# Altium schematic sheet Sensor.SchDoc
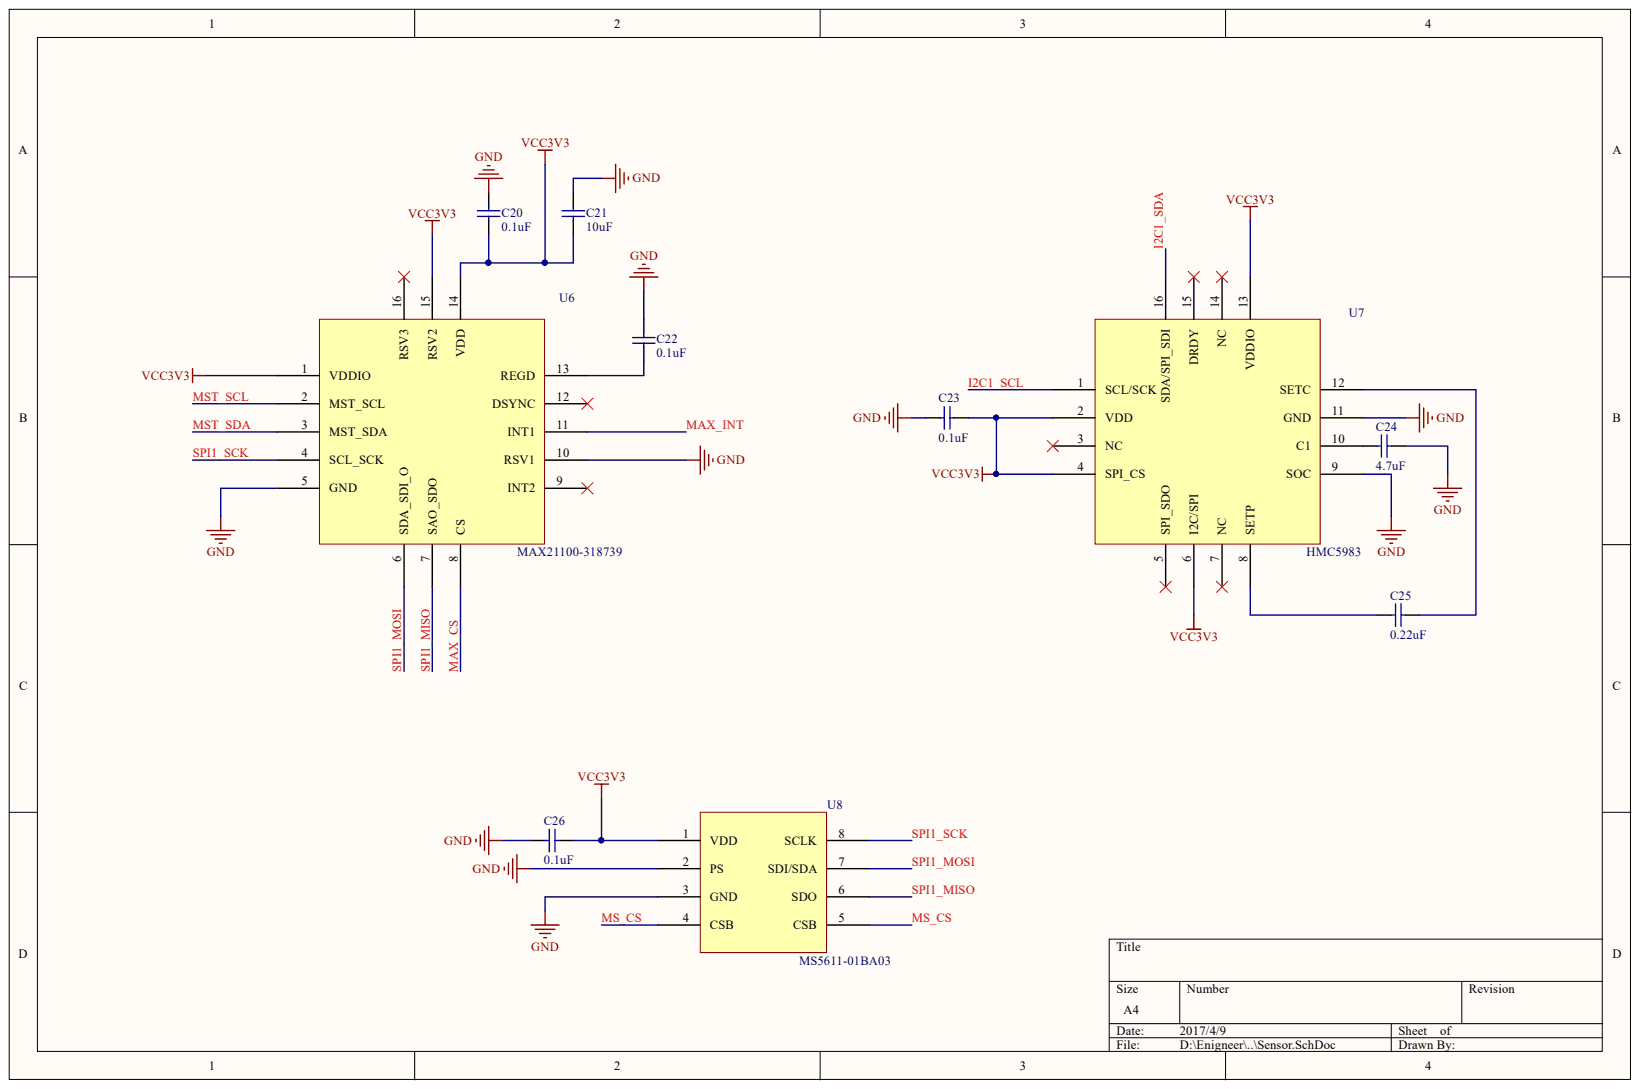
[diagram p0: Sensor.SchDoc]

## Parts
C20  value 0.1uF  [0603C]  (hidden comment: Cap)
C21  value 10uF  [0603C]  (hidden comment: Cap)
C22  value 0.1uF  [0603C]  (hidden comment: Cap)
C23  value 0.1uF  [0603C]  (hidden comment: Cap)
C24  value 4.7uF  [0603C]  (hidden comment: Cap)
C25  value 0.22uF  [0603C]  (hidden comment: Cap)
C26  value 0.1uF  [0603C]  (hidden comment: Cap)
U6  MAX21100-318739  [MAX21100-LCC]
U7  HMC5983  [HMC5983-LCC]
U8  MS5611-01BA03  [MS5611-QFN]

## Nets
GND: C20.2 C21.2 C22.2 C23.2 C24.1 C26.2 U6.5(GND) U6.10(RSV1) U7.9(SOC) U7.11(GND) U8.2(PS) U8.3(GND)
VCC3V3: C20.1 C21.1 C23.1 C26.1 U6.1(VDDIO) U6.14(VDD) U6.15(RSV2) U7.2(VDD) U7.4(SPI_CS) U7.6(I2C/SPI) U7.13(VDDIO) U8.1(VDD)
I2C1_SCL: U7.1(SCL/SCK)
I2C1_SDA: U7.16(SDA/SPI_SDI)
MAX_CS: U6.8(CS)
MAX_INT: U6.11(INT1)
MS_CS: U8.4(CSB) U8.5(CSB)
MST_SCL: U6.2(MST_SCL)
MST_SDA: U6.3(MST_SDA)
SPI1_MISO: U6.7(SAO_SDO) U8.6(SDO)
SPI1_MOSI: U6.6(SDA_SDI_O) U8.7(SDI/SDA)
SPI1_SCK: U6.4(SCL_SCK) U8.8(SCLK)
NetC22_1: C22.1 U6.13(REGD)
NetC24_2: C24.2 U7.10(C1)
NetC25_1: C25.1 U7.12(SETC)
NetC25_2: C25.2 U7.8(SETP)

Not connected: U6 9(INT2) 12(DSYNC) 16(RSV3); U7 3(NC) 5(SPI_SDO) 7(NC) 14(NC) 15(DRDY)

## Library data of the parts
C20-C26 = Cap: Capacitor; Component Kind: Standard; LatestRevisionDate: 17-Jul-2002; LatestRevisionNote: Re-released for DXP Platform.; Library Name: Miscellaneous Devices.IntLib; PackageDescription: Radial Cap, Thru-Hole; 2 Leads; 0.3 in Pin Spacing; PackageReference: RAD-0.3; Published: 8-Jun-2000; Signal Integrity: Cap; Simulation: CAP; Publisher: Altium Limited
U6 = MAX21100: Component Kind: Standard; Library Name: pxLib.SchLib
U8 = MS5611: Component Kind: Standard; Library Name: pxLib.SchLib
U7 = HMC5983: Component Kind: Standard; Library Name: pxLib.SchLib
